# Altium schematic sheet microcontroller&outputdispay.SchDoc
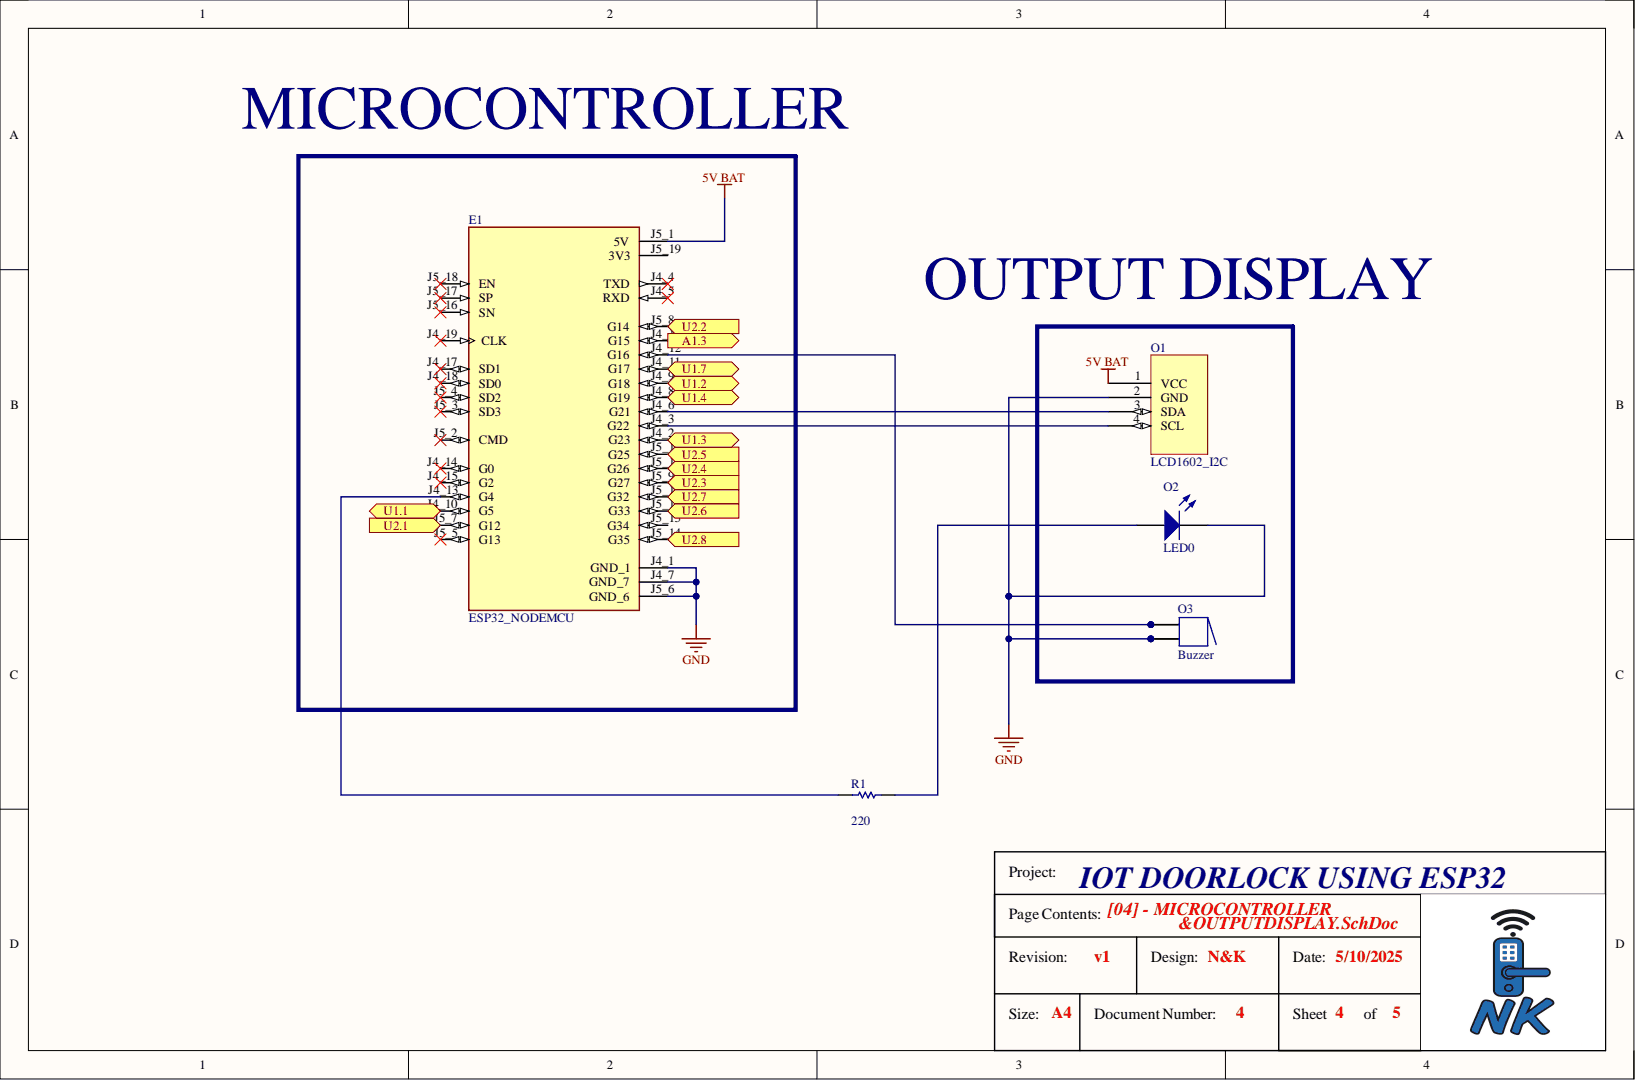
[diagram p0: microcontroller&outputdispay.SchDoc]

## Parts
E1  ESP32_NODEMCU  [MODULE_ESP32_NODEMCU]
O1  LCD1602_I2C
O2  LED0  [LED-0]
O3  Buzzer  [ABSM-1574]
R1  value 220  [AXIAL-0.3]

## Ports
A1.3, U1.1, U1.2, U1.3, U1.4, U1.7, U2.1, U2.2, U2.3, U2.4, U2.5, U2.6, U2.7, U2.8

## Nets
5V BAT: E1.J5_1(5V) O1.1(VCC)
GND: E1.J4_1(GND_1) E1.J4_7(GND_7) E1.J5_6(GND_6) O1.2(GND) O2.2 O3.2 O3.2A
A1.3: E1.J4_16(G15) ; port A1.3
U1.1: E1.J4_10(G5) ; port U1.1
U1.2: E1.J4_9(G18) ; port U1.2
U1.3: E1.J4_2(G23) ; port U1.3
U1.4: E1.J4_8(G19) ; port U1.4
U1.7: E1.J4_11(G17) ; port U1.7
U2.1: E1.J5_7(G12) ; port U2.1
U2.2: E1.J5_8(G14) ; port U2.2
U2.3: E1.J5_9(G27) ; port U2.3
U2.4: E1.J5_10(G26) ; port U2.4
U2.5: E1.J5_11(G25) ; port U2.5
U2.6: E1.J5_12(G33) ; port U2.6
U2.7: E1.J5_13(G32) ; port U2.7
U2.8: E1.J5_14(G35) ; port U2.8
NetE1_J4_3: E1.J4_3(G22) O1.4(SCL)
NetE1_J4_6: E1.J4_6(G21) O1.3(SDA)
NetE1_J4_12: E1.J4_12(G16) O3.1 O3.1A
NetE1_J4_13: E1.J4_13(G4) R1.1
NetO2_1: O2.1 R1.2

Not connected: E1 J4_4(TXD) J4_5(RXD) J4_14(G0) J4_15(G2) J4_17(SD1) J4_18(SD0) J4_19(CLK) J5_2(CMD) J5_3(SD3) J5_4(SD2) J5_5(G13) J5_15(G34) J5_16(SN) J5_17(SP) J5_18(EN) J5_19(3V3)

## Library data of the parts
E1 = ESP32_NODEMCU: NodeMCU is an open source loT platform. ESP32 is a series of low cost, low powersystem-on-chip (SoC) microcontrollers with integrated Wi-Fi and dual-mode Bluetooth.; MF: AZ Delivery; MP: ESP32 NODEMCU; Check_prices: https://www.snapeda.com/parts/ESP32%20NODEMCU/AZ+Displays/view-part/?ref=eda; Component Kind: Standard; Library Name: ESP32_NODEMCU.IntLib; Package: Package; SnapEDA_Link: https://www.snapeda.com/parts/ESP32%20NODEMCU/AZ+Displays/view-part/?ref=snap
O2 = LED0: Typical INFRARED GaAs LED; Component Kind: Standard; LatestRevisionDate: 08-Jul-2005; LatestRevisionNote: Mech Layer 1 removed.; Library Name: Miscellaneous Devices.IntLib; PackageDescription: LED; 2 Leads; PackageReference: LED-0; Published: 8-Jun-2000; Simulation: LED0; Publisher: Altium Limited
O3 = Buzzer: Magnetic Transducer Buzzer; Component Kind: Standard; ComponentLink1Description: Manufacturer Link; ComponentLink1URL: http://www.megastar.com/linecard/buzzmode/index.php; DatasheetVersion: 17-Dec-2003; LatestRevisionDate: 17-Dec-2003; LatestRevisionNote: Schematic revised, PCB Footprint replaced.; Library Name: Miscellaneous Devices.IntLib; PackageDescription: Magnetic Transducer Buzzer; PackageReference: ABSM-1574; Published: 24-Mar-1999; Publisher: Altium Limited
R1 = Res1: Resistor; Component Kind: Standard; LatestRevisionDate: 17-Jul-2002; LatestRevisionNote: Re-released for DXP Platform.; Library Name: Miscellaneous Devices.IntLib; PackageDescription: Axial Device, Thru-Hole; 2 Leads; 0.3 in Pin Spacing; PackageReference: AXIAL-0.3; Published: 8-Jun-2000; Signal Integrity: Res; Simulation: RESISTOR; Publisher: Altium Limited
O1 = LCD1602_I2C: Component Kind: Standard; Library Name: Schlib1.SchLib
